# Altium schematic sheet shutdown_circuit.SchDoc(shutdown_circuit)
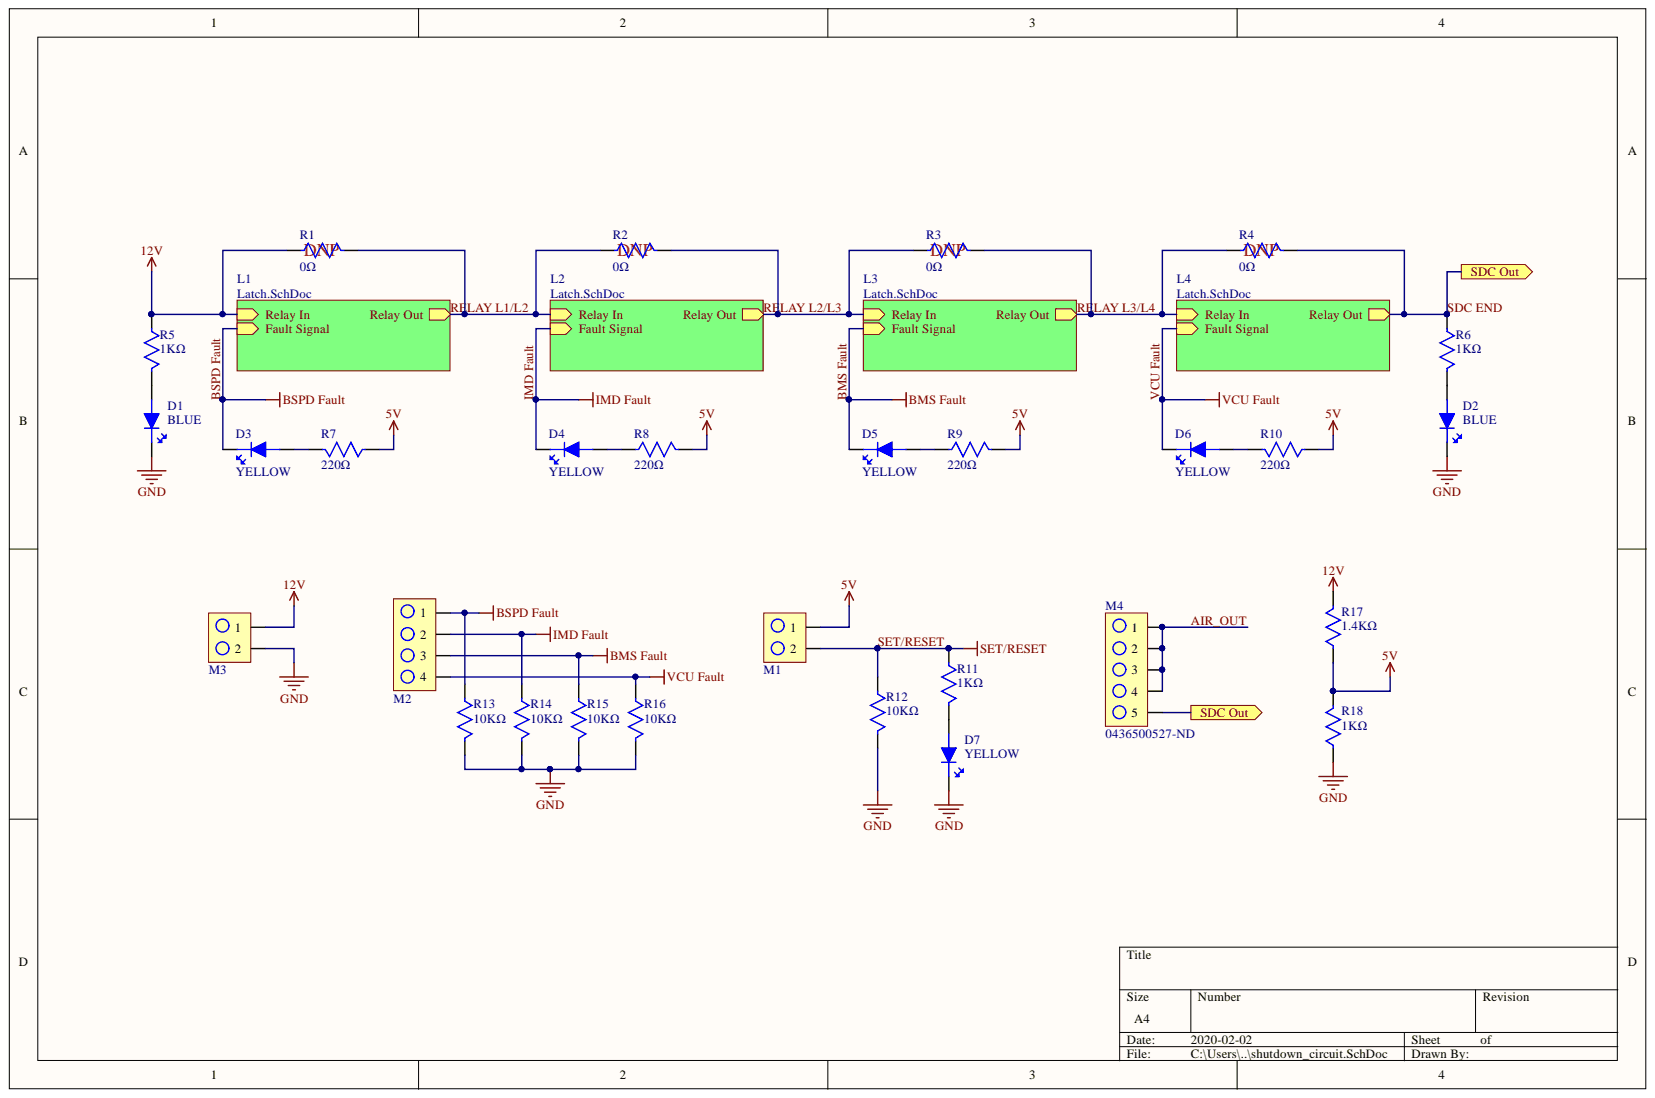
[diagram p0: shutdown_circuit.SchDoc]

## Parts
D1  BLUE  [LED 0603]
D2  BLUE  [LED 0603]
D3  YELLOW  [LED 0603]
D4  YELLOW  [LED 0603]
D5  YELLOW  [LED 0603]
D6  YELLOW  [LED 0603]
D7  YELLOW  [LED 0603]
M1  0436500228  [2-PIN MOLEX]  (value not drawn)
M2  0436500428  [4-PIN MOLEX]  (value not drawn)
M3  0436500228  [2-PIN MOLEX]  (value not drawn)
M4  Supplier Part Number 1: 0436500527-ND  [5-PIN MOLEX]  (hidden comment: 5pin)
R1  0Ω  [RESISTOR 1210]
R2  0Ω  [RESISTOR 1210]
R3  0Ω  [RESISTOR 1210]
R4  0Ω  [RESISTOR 1210]
R5  1KΩ  [RESISTOR 0603]
R6  1KΩ  [RESISTOR 0603]
R7  220Ω  [RESISTOR 0603]
R8  220Ω  [RESISTOR 0603]
R9  220Ω  [RESISTOR 0603]
R10  220Ω  [RESISTOR 0603]
R11  1KΩ  [RESISTOR 0603]
R12  10KΩ  [RESISTOR 0603]
R13  10KΩ  [RESISTOR 0603]
R14  10KΩ  [RESISTOR 0603]
R15  10KΩ  [RESISTOR 0603]
R16  10KΩ  [RESISTOR 0603]
R17  1.4KΩ  [RESISTOR 0603]
R18  1KΩ  [RESISTOR 0603]

## Ports
SDC Out

## Nets
5V: M1.1 R7.1 R8.2 R9.2 R10.1 R17.1 R18.2
12V: M3.1 R1.1 R5.1 R17.2
GND: D1.2 D2.2 D7.2 M3.2 R12.2 R13.2 R14.2 R15.2 R16.2 R18.1
AIR_OUT: M4.1 M4.2 M4.3 M4.4
BMS Fault: D5.2 M2.3 R15.1
BSPD Fault: D3.2 M2.1 R13.1
IMD Fault: D4.2 M2.2 R14.1
RELAY L1/L2: R1.2 R2.1
RELAY L2/L3: R2.2 R3.1
RELAY L3/L4: R3.2 R4.1
SDC END: M4.5 R4.2 R6.1 ; port SDC Out
SET/RESET: M1.2 R11.1 R12.1
VCU Fault: D6.2 M2.4 R16.1
NetD1_1: D1.1 R5.2
NetD2_1: D2.1 R6.2
NetD3_1: D3.1 R7.2
NetD4_1: D4.1 R8.1
NetD5_1: D5.1 R9.1
NetD6_1: D6.1 R10.2
NetD7_1: D7.1 R11.2

## Library data of the parts
D1 D2 = LTST-C191TBKT-5A: LED BLUE CLEAR CHIP SMD; Manufacturer: Vishay Lite-On; Voltage: 29V; Viewing Angle: 130°; Test Current: 5mA; Number of LEDs: 1; Luminous Intensity: 15mcd; Lens Style: Diffused,Colorless,Rectangular; Lens Color: Colorless; Forward Voltage: 2.8V; Forward Current: 20mA; Dominant Wavelength: 473nm; Width: 0.8mm; Supplier Part Number 1: 160-2212-6-ND; Supplier 1: Digi-Key; Stock 1: 9654; Radiation Hardening: No; Pricing 1: 1=0.5, 10=0.359, 100=0.1596, 500=0.11172, 1000=0.09177, 2500=0.0798 (USD); Packaging: Tape and Reel; Package Quantity: 5000; Number of Pins: 2; Mount: Surface Mount; Min Operating Temperature: -20°C; Max Operating Temperature: 80°C; Manufacturer Part Number: LTST-C191TBKT-5A; Lens Transparency: Clear; Length: 1.6mm; Illumination Color: Blue; Height: 0.55mm; ComponentLink4URL: http://www.mouser.com/ds/2/239/LTST-C191TBKT-5A-1150649.pdf?src-supplier=Mouser; ComponentLink4Description: http://www.mouser.com/ds/2/239/LTST-C191TBKT-5A-1150649.pdf?src-supplier=Mouser; ComponentLink3URL: http://optoelectronics.liteon.com/zh-cn/api/DwonloadFileHandler.ashx?txtSpecNo=DS22-2003-020&txtPartNo=LTST-C191TBKT-5A&src-supplier=Avnet; ComponentLink3Description: http://optoelectronics.liteon.com/zh-cn/api/DwonloadFileHandler.ashx?txtSpecNo=DS22-2003-020&txtPartNo=LTST-C191TBKT-5A&src-supplier=Avnet; ComponentLink2URL: http://components.arrow.com/part/dataview/52218576S9813001N1331?src-supplier=Arrow; ComponentLink2Description: http://components.arrow.com/part/dataview/52218576S9813001N1331?src-supplier=Arrow; ComponentLink1URL: http://optoelectronics.liteon.com/upload/download/DS22-2003-020/LTST-C191TBKT-5A.pdf?src-supplier=Digi-Key; ComponentLink1Description: http://optoelectronics.liteon.com/upload/download/DS22-2003-020/LTST-C191TBKT-5A.pdf?src-supplier=Digi-Key; Component Kind: Standard; Color: Blue; Category: Optoelectronics; Case Code (Metric): 1608; Case Code (Imperial): 0603; Case/Package: 0603; Library Name: sdc_prech_schlib.SchLib
D3-D7 = LTST-C191KSKT: LED YELLOW CLEAR SMD; Manufacturer: Vishay Lite-On; Wavelength: 588nm; Viewing Angle: 130°; Test Current: 20mA; Reverse Voltage: 5V; Power Rating: 75mW; Number of LEDs: 1; Luminous Intensity: 180mcd; Lens Style: Diffused,Colorless,Rectangular,Clear; Lens Color: Colorless; Forward Voltage: 2.4V; Forward Current: 30mA; Dominant Wavelength: 597nm; Width: 0.8mm; Supplier Part Number 1: 160-1448-6-ND; Supplier 1: Digi-Key; Stock 1: 464545; Radiation Hardening: No; Pricing 1: 1=0.29, 10=0.215, 100=0.1016, 500=0.06774, 1000=0.05306, 2500=0.05194 (USD); Power Dissipation: 75mW; Packaging: Tape and Reel; Package Quantity: 1; Number of Pins: 2; Number of Elements: 1; Mount: Surface Mount; Min Operating Temperature: -55°C; Max Operating Temperature: 85°C; Manufacturer Part Number: LTST-C191KSKT; Lens Transparency: Clear; Length: 1.6mm; Lead Free: Lead Free; Illumination Color: Yellow; Height: 0.55mm; Depth: 0.8mm; ComponentLink4URL: http://www1.futureelectronics.com/doc/LITE%20ON/LITE-ON%20LTST-C191KSKT.pdf?src-supplier=Future+Electronics; ComponentLink4Description: http://www1.futureelectronics.com/doc/LITE%20ON/LITE-ON%20LTST-C191KSKT.pdf?src-supplier=Future+Electronics; ComponentLink3URL: http://www.mouser.com/ds/2/239/LTST-C191KSKT-1141271.pdf?src-supplier=Mouser; ComponentLink3Description: http://www.mouser.com/ds/2/239/LTST-C191KSKT-1141271.pdf?src-supplier=Mouser; ComponentLink2URL: https://www.verical.com/server-webapp/html/getDatasheet?partID=141495&src-supplier=Verical; ComponentLink2Description: https://www.verical.com/server-webapp/html/getDatasheet?partID=141495&src-supplier=Verical; ComponentLink1URL: http://optoelectronics.liteon.com/upload/download/DS22-2000-224/LTST-C191KSKT.PDF?src-supplier=Digi-Key; ComponentLink1Description: http://optoelectronics.liteon.com/upload/download/DS22-2000-224/LTST-C191KSKT.PDF?src-supplier=Digi-Key; Component Kind: Standard; Color: Yellow; Category: Optoelectronics; Case Code (Metric): 1608; Case Code (Imperial): 0603; Case/Package: 0603; Library Name: sdc_prech_schlib.SchLib
M1 M3 = 0436500228: Conn Power HDR 2 POS 3mm Solder ST Thru-Hole 2 Terminal 1 Port Micro-Fit 3.0™ Tray; Manufacturer: Molex; Number of Positions: 2; Number of Ports: 1; Connector Type: Male Pin; Termination: Solder; Supplier Part Number 1: WM10658-ND; Supplier 1: Digi-Key; Stock 1: 9247; Pricing 1: 1=0.9, 10=0.795, 100=0.6857, 500=0.56106, 1000=0.49872, 5000=0.43638, 10000=0.41456 (USD); Pitch: 3mm; Packaging: Tray; Package Quantity: 3264; Orientation: Straight; Number of Terminals: 2; Number of Rows: 1; Number of Contacts: 2; Mount: Through Hole; Min Operating Temperature: -40°C; Max Operating Temperature: 105°C; Manufacturer Part Number: 0436500228; Length: 9.65mm; Housing Material: Polymer; Fastening Type: Ramp; Depth: 5.57mm; Contact Plating: Gold; Contact Material: Brass; ComponentLink2URL: http://components.arrow.com/part/dataview/2942358S8703676N3303?src-supplier=Arrow; ComponentLink2Description: http://components.arrow.com/part/dataview/2942358S8703676N3303?src-supplier=Arrow; ComponentLink1URL: http://www.molex.com/pdm_docs/sd/436500228_sd.pdf?src-supplier=Digi-Key; ComponentLink1Description: http://www.molex.com/pdm_docs/sd/436500228_sd.pdf?src-supplier=Digi-Key; Component Kind: Standard; Color: Black; Category: Connectors, Interconnects; Library Name: sdc_prech_schlib.SchLib
M2 = 0436500428: Conn Power HDR 4 POS 3mm Solder ST Thru-Hole 4 Terminal 1 Port Micro-Fit 3.0™ Tray; Manufacturer: Molex; Number of Positions: 4; Number of Ports: 1; Connector Type: Male Pin; Termination: Solder; Supplier Part Number 1: WM10669-ND; Supplier 1: Digi-Key; Stock 1: 1193; Pricing 1: 1=1.44, 10=1.265, 100=1.0916, 500=0.89316, 1000=0.79392, 5000=0.69468, 10000=0.65995 (USD); Pitch: 3mm; Packaging: Tray; Package Quantity: 2040; Orientation: Straight; Number of Terminals: 4; Number of Rows: 1; Number of Contacts: 4; Mount: Through Hole; Min Operating Temperature: -40°C; Max Operating Temperature: 105°C; Manufacturer Part Number: 0436500428; Length: 15.65mm; Housing Material: Polymer; Fastening Type: Ramp; Depth: 5.57mm; Contact Plating: Gold; Contact Material: Brass; ComponentLink2URL: http://www.molex.com/webdocs/datasheets/pdf/en-us/0436500428_PCB_HEADERS.pdf?src-supplier=Avnet; ComponentLink2Description: http://www.molex.com/webdocs/datasheets/pdf/en-us/0436500428_PCB_HEADERS.pdf?src-supplier=Avnet; ComponentLink1URL: http://www.molex.com/pdm_docs/sd/436500428_sd.pdf?src-supplier=Digi-Key; ComponentLink1Description: http://www.molex.com/pdm_docs/sd/436500428_sd.pdf?src-supplier=Digi-Key; Component Kind: Standard; Color: Black; Category: Connectors, Interconnects; Library Name: sdc_prech_schlib.SchLib
M4 = 0436500527: CONN HEADER VERT 5POS 3MM; Supplier 1: Digi-Key; Component Kind: Standard; Library Name: sdc_prech_schlib.SchLib
R1-R4 = CRCW12100000Z0EA: RES SMD 0 OHM JUMPER 1/2W 1210; Manufacturer: Vishay Dale; Voltage Rating: 200V; Temperature Coefficient: 0ppm/°C; Resistance: 0mR; Power Rating: 500mW; Width: 0.098inch; Weight: 0.000564oz; Termination: SMD/SMT; Supplier Part Number 1: 541-0.0VDKR-ND; Supplier 1: Digi-Key; Stock 1: 916328; REACH SVHC: No SVHC; Radiation Hardening: No; Pricing 1: 1=0.21, 10=0.182, 25=0.132, 50=0.0958, 100=0.071, 250=0.05444, 500=0.0429, 1000=0.0297 (USD); Packaging: Tape and Reel; Package Quantity: 1; Number of Terminations: 2; Mount: Surface Mount; Min Operating Temperature: -55°C; Military Standard: Not; Max Power Dissipation: 500mW; Max Operating Temperature: 155°C; Manufacturer Part Number: CRCW12100000Z0EA; Length: 3.2mm; Height: 0.55mm; Features: Automotive AEC-Q200; Depth: 2.5mm; Contact Plating: Tin; Composition: Thick Film; ComponentLink8URL: http://uk.rs-online.com/webdocs/0d98/0900766b80d98bd1.pdf?src-supplier=RSComponents; ComponentLink8Description: http://uk.rs-online.com/webdocs/0d98/0900766b80d98bd1.pdf?src-supplier=RSComponents; ComponentLink7URL: http://www.vishay.com/docs/20035/dcrcwe3.pdf?src-supplier=Avnet+Europe; ComponentLink7Description: http://www.vishay.com/docs/20035/dcrcwe3.pdf?src-supplier=Avnet+Europe; ComponentLink6URL: http://www.vishay.com/docs/20035/dcrcwe3.pdf?src-supplier=Avnet; ComponentLink6Description: http://www.vishay.com/docs/20035/dcrcwe3.pdf?src-supplier=Avnet; ComponentLink5URL: https://www.alliedelec.com/m/d/0c934d6939ccefa68dbe0b96d821c8cf.pdf?src-supplier=Allied+Electronics; ComponentLink5Description: https://www.alliedelec.com/m/d/0c934d6939ccefa68dbe0b96d821c8cf.pdf?src-supplier=Allied+Electronics; ComponentLink4URL: http://www.mouser.com/ds/2/427/dcrcwe3-109170.pdf?src-supplier=Mouser; ComponentLink4Description: http://www.mouser.com/ds/2/427/dcrcwe3-109170.pdf?src-supplier=Mouser; ComponentLink3URL: http://www.farnell.com/datasheets/2793682.pdf?src-supplier=Element14; ComponentLink3Description: http://www.farnell.com/datasheets/2793682.pdf?src-supplier=Element14; ComponentLink2URL: http://www.vishay.com/docs/20035/dcrcwe3.pdf?src-supplier=Future+Electronics; ComponentLink2Description: http://www.vishay.com/docs/20035/dcrcwe3.pdf?src-supplier=Future+Electronics; ComponentLink1URL: http://www.vishay.com/docs/20035/dcrcwe3.pdf?src-supplier=Digi-Key; ComponentLink1Description: http://www.vishay.com/docs/20035/dcrcwe3.pdf?src-supplier=Digi-Key; Component Kind: Standard; Category: Resistors; Case Code (Metric): 3225; Case Code (Imperial): 1210; Case/Package: 1210; Library Name: sdc_prech_schlib.SchLib
R5 R6 R11 R18 = CRCW06031K00FKEA: RES SMD 1K OHM 1% 1/10W 0603; Manufacturer: Vishay Dale; Voltage Rating (DC): 75V; Temperature Coefficient: 100ppm/°C; Resistance: 1kR; Power Rating: 100mW; Width: 0.033inch; Weight: 7.1E-05oz; Voltage Rating: 75V; Tolerance: 1%; Termination: SMD/SMT; Supplier Part Number 1: 541-1.00KHCT-ND; Supplier 1: Digi-Key; Stock 1: 1811474; REACH SVHC: Unknown; Radiation Hardening: No; Pricing 1: 1=0.1, 10=0.034, 100=0.0139, 1000=0.00623, 2500=0.0054 (USD); Packaging: Tape and Reel; Package Quantity: 10; Number of Terminations: 2; Number of Pins: 2; Mount: Surface Mount; Min Operating Temperature: -55°C; Military Standard: Not; Max Power Dissipation: 100mW; Max Operating Temperature: 155°C; Manufacturer Part Number: CRCW06031K00FKEA; Length: 1.55mm; Lead Free: Lead Free; Height: 0.45mm; Features: Automotive AEC-Q200; Depth: 0.85mm; Contact Plating: Tin; Composition: Thick Film; ComponentLink8URL: http://www.vishay.com/docs/20035/dcrcwe3.pdf?src-supplier=Avnet+Europe; ComponentLink8Description: http://www.vishay.com/docs/20035/dcrcwe3.pdf?src-supplier=Avnet+Europe; ComponentLink7URL: http://www.vishay.com/docs/20035/dcrcwe3.pdf?src-supplier=Future+Electronics; ComponentLink7Description: http://www.vishay.com/docs/20035/dcrcwe3.pdf?src-supplier=Future+Electronics; ComponentLink6URL: https://www.distrelec.biz/Web/Downloads/a_/en/flD-CRCW-e3_data_en.pdf?mime=application%2Fpdf&src-supplier=Distrelec; ComponentLink6Description: https://www.distrelec.biz/Web/Downloads/a_/en/flD-CRCW-e3_data_en.pdf?mime=application%2Fpdf&src-supplier=Distrelec; ComponentLink5URL: http://www.farnell.com/datasheets/2310790.pdf?src-supplier=Element14; ComponentLink5Description: http://www.farnell.com/datasheets/2310790.pdf?src-supplier=Element14; ComponentLink4URL: http://www.vishay.com/docs/20035/dcrcwe3.pdf?src-supplier=Avnet+Asia; ComponentLink4Description: http://www.vishay.com/docs/20035/dcrcwe3.pdf?src-supplier=Avnet+Asia; ComponentLink3URL: http://www.vishay.com/docs/20035/dcrcwe3.pdf?src-supplier=Avnet; ComponentLink3Description: http://www.vishay.com/docs/20035/dcrcwe3.pdf?src-supplier=Avnet; ComponentLink2URL: http://uk.rs-online.com/webdocs/0d98/0900766b80d98afc.pdf?src-supplier=RSComponents; ComponentLink2Description: http://uk.rs-online.com/webdocs/0d98/0900766b80d98afc.pdf?src-supplier=RSComponents; ComponentLink1URL: http://www.vishay.com/docs/20035/dcrcwe3.pdf?src-supplier=Digi-Key; ComponentLink1Description: http://www.vishay.com/docs/20035/dcrcwe3.pdf?src-supplier=Digi-Key; Component Kind: Standard; Category: Resistors; Case Code (Metric): 1608; Case Code (Imperial): 0603; Case/Package: 0603; Library Name: sdc_prech_schlib.SchLib
R7-R10 = CRCW0603220RJNEA: RES SMD 220 OHM 5% 1/10W 0603; Manufacturer: Vishay; Working Voltage: 75V; Temperature Coefficient: 200ppm/°C; Resistance: 220R; Power Rating: 100mW; Width: 0.033inch; Weight: 7.1E-05oz; Voltage Rating: 75V; Tolerance: 5%; Termination: SMD/SMT; Supplier Part Number 1: 541-220GCT-ND; Supplier 1: Digi-Key; Stock 1: 171552; REACH SVHC: Unknown; Radiation Hardening: No; Pricing 1: 1=0.1, 10=0.031, 100=0.0125, 1000=0.00562, 2500=0.00488 (USD); Packaging: Tape and Reel; Package Quantity: 1; Number of Terminations: 2; Mount: Surface Mount; Min Operating Temperature: -55°C; Military Standard: Not; Max Power Dissipation: 100mW; Max Operating Temperature: 155°C; Manufacturer Part Number: CRCW0603220RJNEA; Length: 1.55mm; Height: 0.45mm; Features: Automotive AEC-Q200; Depth: 0.85mm; Contact Plating: Tin; Composition: Thick Film; ComponentLink5URL: http://www.mouser.com/ds/2/427/dcrcwe3-109170.pdf?src-supplier=Mouser; ComponentLink5Description: http://www.mouser.com/ds/2/427/dcrcwe3-109170.pdf?src-supplier=Mouser; ComponentLink4URL: http://www.farnell.com/datasheets/2045673.pdf?src-supplier=Element14; ComponentLink4Description: http://www.farnell.com/datasheets/2045673.pdf?src-supplier=Element14; ComponentLink3URL: http://www.alliedelec.com/m/d/0c934d6939ccefa68dbe0b96d821c8cf.pdf?src-supplier=Allied+Electronics; ComponentLink3Description: http://www.alliedelec.com/m/d/0c934d6939ccefa68dbe0b96d821c8cf.pdf?src-supplier=Allied+Electronics; ComponentLink2URL: http://www.vishay.com/docs/20035/dcrcwe3.pdf?src-supplier=Avnet; ComponentLink2Description: http://www.vishay.com/docs/20035/dcrcwe3.pdf?src-supplier=Avnet; ComponentLink1URL: http://www.vishay.com/docs/20035/dcrcwe3.pdf?src-supplier=Digi-Key; ComponentLink1Description: http://www.vishay.com/docs/20035/dcrcwe3.pdf?src-supplier=Digi-Key; Component Kind: Standard; Category: Resistors; Case Code (Metric): 1608; Case Code (Imperial): 0603; Case/Package: 0603; Library Name: sdc_prech_schlib.SchLib
R12-R16 = CRCW060310K0FKEA: RES SMD 10K OHM 1% 1/10W 0603; Manufacturer: Vishay; Voltage Rating (DC): 75V; Temperature Coefficient: 100ppm/°C; Resistance: 10kR; Power Rating: 100mW; Width: 0.033inch; Weight: 7.1E-05oz; Voltage Rating: 75V; Tolerance: 1%; Termination: SMD/SMT; Supplier Part Number 1: 541-10.0KHCT-ND; Supplier 1: Digi-Key; Stock 1: 1434373; REACH SVHC: Unknown; Radiation Hardening: No; Pricing 1: 1=0.1, 10=0.034, 100=0.0139, 1000=0.00623, 2500=0.0054 (USD); Packaging: Tape and Reel; Package Quantity: 10; Number of Terminations: 2; Number of Pins: 2; Min Operating Temperature: -55°C; Military Standard: Not; Max Power Dissipation: 100mW; Max Operating Temperature: 155°C; Manufacturer Part Number: CRCW060310K0FKEA; Length: 1.55mm; Lead Free: Lead Free; Height: 0.45mm; Features: Automotive AEC-Q200; Depth: 0.85mm; Contact Plating: Tin,Lead; Composition: Thick Film; ComponentLink10URL: http://www.farnell.com/datasheets/2310790.pdf?src-supplier=Distrelec; ComponentLink10Description: http://www.farnell.com/datasheets/2310790.pdf?src-supplier=Distrelec; ComponentLink9URL: http://uk.rs-online.com/webdocs/0d98/0900766b80d98afc.pdf?src-supplier=RSComponents; ComponentLink9Description: http://uk.rs-online.com/webdocs/0d98/0900766b80d98afc.pdf?src-supplier=RSComponents; ComponentLink8URL: http://www.vishay.com/docs/20035/dcrcwe3.pdf?src-supplier=Future+Electronics; ComponentLink8Description: http://www.vishay.com/docs/20035/dcrcwe3.pdf?src-supplier=Future+Electronics; ComponentLink7URL: http://www.farnell.com/datasheets/2310790.pdf?src-supplier=Avnet; ComponentLink7Description: http://www.farnell.com/datasheets/2310790.pdf?src-supplier=Avnet; ComponentLink6URL: http://www.farnell.com/datasheets/2310790.pdf?src-supplier=Element14; ComponentLink6Description: http://www.farnell.com/datasheets/2310790.pdf?src-supplier=Element14; ComponentLink5URL: http://www.farnell.com/datasheets/2310790.pdf?src-supplier=Mouser; ComponentLink5Description: http://www.farnell.com/datasheets/2310790.pdf?src-supplier=Mouser; ComponentLink4URL: http://www.vishay.com/docs/20035/dcrcwe3.pdf?src-supplier=Digi-Key; ComponentLink4Description: http://www.vishay.com/docs/20035/dcrcwe3.pdf?src-supplier=Digi-Key; ComponentLink3URL: http://www.farnell.com/datasheets/2310790.pdf?src-supplier=Allied+Electronics; ComponentLink3Description: http://www.farnell.com/datasheets/2310790.pdf?src-supplier=Allied+Electronics; ComponentLink2URL: https://www.verical.com/server-webapp/html/getDatasheet?partID=86831&src-supplier=Verical; ComponentLink2Description: https://www.verical.com/server-webapp/html/getDatasheet?partID=86831&src-supplier=Verical; ComponentLink1URL: http://www.vishay.com/docs/20008/dcrcw.pdf?src-supplier=Avnet+Europe; ComponentLink1Description: http://www.vishay.com/docs/20008/dcrcw.pdf?src-supplier=Avnet+Europe; Component Kind: Standard; Category: Resistors; Case Code (Metric): 1608; Case Code (Imperial): 0603; Case/Package: 0603; Library Name: sdc_prech_schlib.SchLib
R17 = CRCW06031K40FKEA: RES SMD 1.4K OHM 1% 1/10W 0603; Manufacturer: Vishay; Working Voltage: 75V; Temperature Coefficient: 100ppm/°C; Resistance: 1.4kR; Power Rating: 100mW; Width: 0.033inch; Weight: 7.1E-05oz; Voltage Rating (DC): 75V; Voltage Rating: 75V; Tolerance: 1%; Termination: SMD/SMT; Supplier Part Number 1: 541-1.40KHCT-ND; Supplier 1: Digi-Key; Stock 1: 516; REACH SVHC: Unknown; Radiation Hardening: No; Pricing 1: 1=0.1, 10=0.034, 100=0.0139, 1000=0.00623, 2500=0.0054 (USD); Packaging: Tape and Reel; Package Quantity: 10; Number of Terminations: 2; Number of Pins: 2; Min Operating Temperature: -55°C; Military Standard: Not; Max Power Dissipation: 100mW; Max Operating Temperature: 155°C; Manufacturer Part Number: CRCW06031K40FKEA; Length: 1.55mm; Lead Free: Lead Free; Height: 0.45mm; Features: Automotive AEC-Q200; Depth: 0.85mm; Contact Plating: Tin; Composition: Thick Film; ComponentLink7URL: http://www.vishay.com/docs/20035/dcrcwe3.pdf?src-supplier=Avnet+Europe; ComponentLink7Description: http://www.vishay.com/docs/20035/dcrcwe3.pdf?src-supplier=Avnet+Europe; ComponentLink6URL: http://uk.rs-online.com/webdocs/0d98/0900766b80d98afc.pdf?src-supplier=RSComponents; ComponentLink6Description: http://uk.rs-online.com/webdocs/0d98/0900766b80d98afc.pdf?src-supplier=RSComponents; ComponentLink5URL: http://www.farnell.com/datasheets/2111203.pdf?src-supplier=Element14; ComponentLink5Description: http://www.farnell.com/datasheets/2111203.pdf?src-supplier=Element14; ComponentLink4URL: http://www.farnell.com/datasheets/2045673.pdf?src-supplier=Element14; ComponentLink4Description: http://www.farnell.com/datasheets/2045673.pdf?src-supplier=Element14; ComponentLink3URL: http://www.vishay.com/doc?20035?src-supplier=Mouser; ComponentLink3Description: http://www.vishay.com/doc?20035?src-supplier=Mouser; ComponentLink2URL: https://www.alliedelec.com/m/d/0c934d6939ccefa68dbe0b96d821c8cf.pdf?src-supplier=Allied+Electronics; ComponentLink2Description: https://www.alliedelec.com/m/d/0c934d6939ccefa68dbe0b96d821c8cf.pdf?src-supplier=Allied+Electronics; ComponentLink1URL: http://www.vishay.com/docs/20035/dcrcwe3.pdf?src-supplier=Digi-Key; ComponentLink1Description: http://www.vishay.com/docs/20035/dcrcwe3.pdf?src-supplier=Digi-Key; Component Kind: Standard; Category: Resistors; Case Code (Metric): 1608; Case Code (Imperial): 0603; Case/Package: 0603; Library Name: sdc_prech_schlib.SchLib
